# Altium schematic sheet AD转化量化器.SchDoc(AD转化量化器)
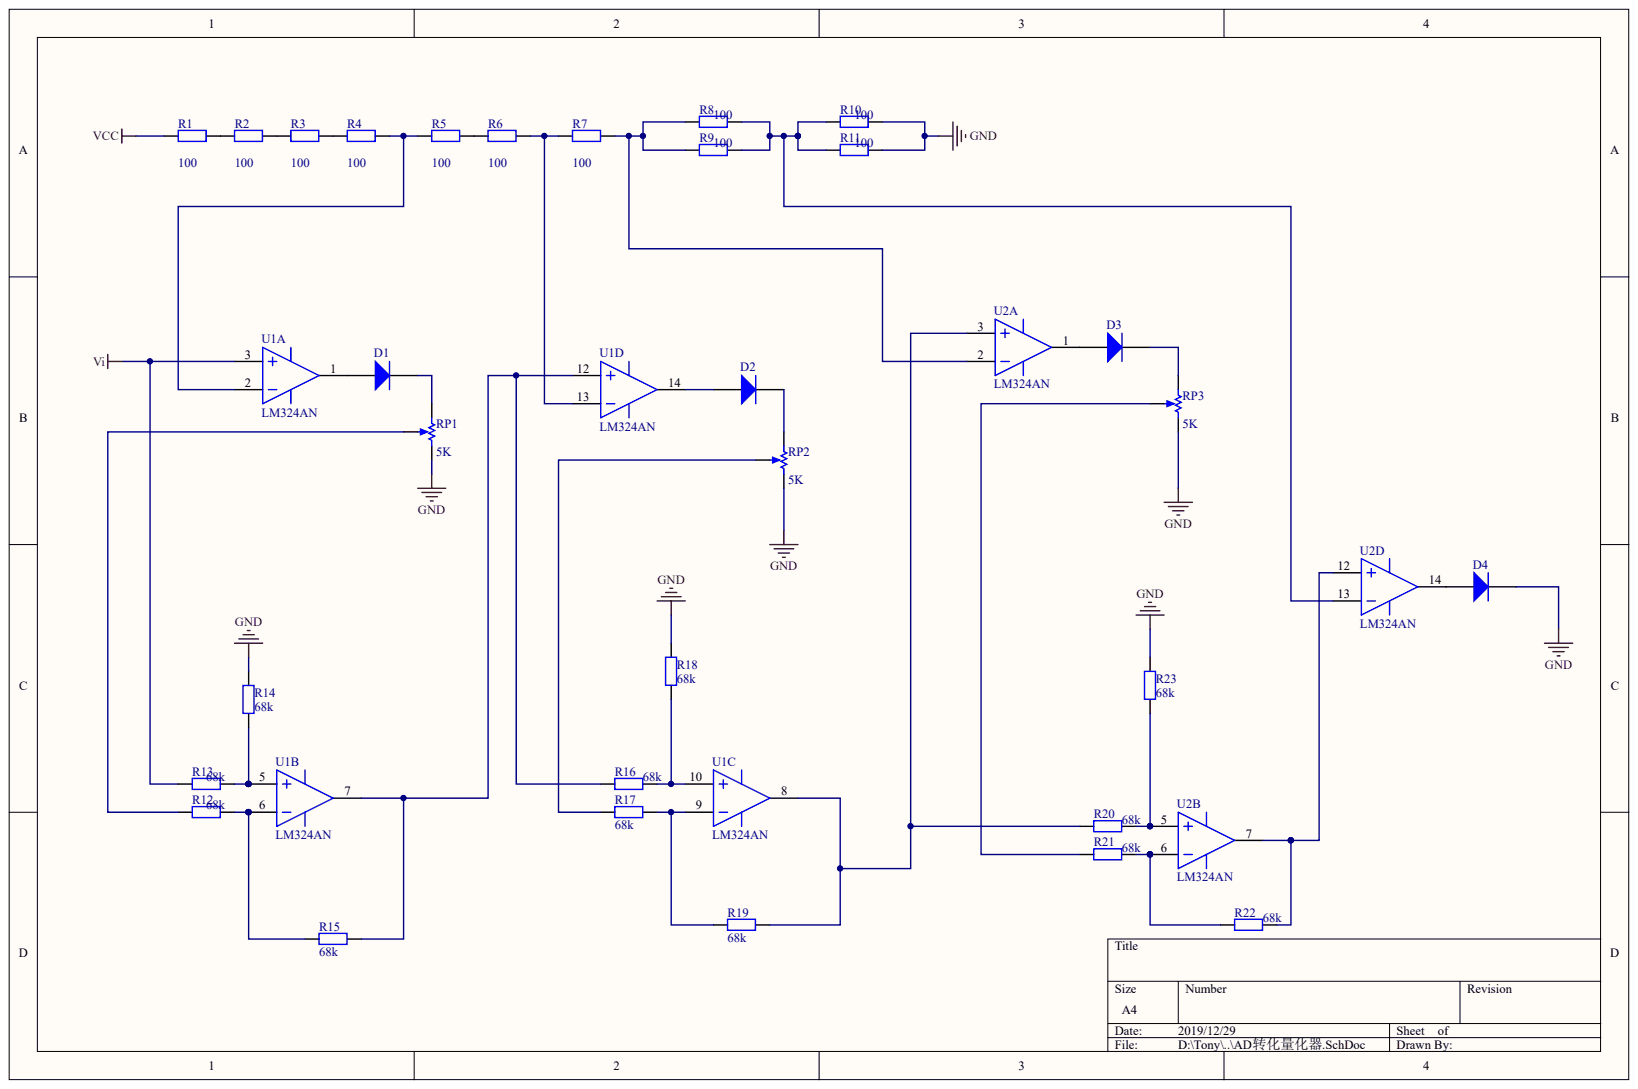
[diagram p0: AD转化量化器.SchDoc]

## Parts
D1  Diode 1N914  (value not drawn)
D2  Diode 1N914  (value not drawn)
D3  Diode 1N914  (value not drawn)
D4  Diode 1N914  (value not drawn)
R1  value 100  (hidden comment: Res2)
R2  value 100  (hidden comment: Res2)
R3  value 100  (hidden comment: Res2)
R4  value 100  (hidden comment: Res2)
R5  value 100  (hidden comment: Res2)
R6  value 100  (hidden comment: Res2)
R7  value 100  (hidden comment: Res2)
R8  value 100  (hidden comment: Res2)
R9  value 100  (hidden comment: Res2)
R10  value 100  (hidden comment: Res2)
R11  Res2  (value not drawn)
R12  value 68k  (hidden comment: Res2)
R13  value 68k  (hidden comment: Res2)
R14  value 68k  (hidden comment: Res2)
R15  value 68k  (hidden comment: Res2)
R16  value 68k  (hidden comment: Res2)
R17  value 68k  (hidden comment: Res2)
R18  value 68k  (hidden comment: Res2)
R19  value 68k  (hidden comment: Res2)
R20  value 68k  (hidden comment: Res2)
R21  value 68k  (hidden comment: Res2)
R22  value 68k  (hidden comment: Res2)
R23  value 68k  (hidden comment: Res2)
RP1  value 5K  (hidden comment: RPot)
RP2  value 5K  (hidden comment: RPot)
RP3  value 5K  (hidden comment: RPot)
U1  LM324AN  (parts A,B,C,D)
U2  LM324AN  (parts A,B,D)

## Nets
GND: D4.2 R10.2 R11.2 R14.2 R18.1 R23.2 RP1.2 RP2.2 RP3.2
VCC: R1.1
VI: R13.1 U1.3
NetD1_1: D1.1 U1.1
NetD1_2: D1.2 RP1.1
NetD2_1: D2.1 U1.14
NetD2_2: D2.2 RP2.1
NetD3_1: D3.1 U2.1
NetD3_2: D3.2 RP3.1
NetD4_1: D4.1 U2.14
NetR1_2: R1.2 R2.1
NetR2_2: R2.2 R3.1
NetR3_2: R3.2 R4.1
NetR4_2: R4.2 R5.1 U1.2
NetR5_2: R5.2 R6.1
NetR6_2: R6.2 R7.1 U1.13
NetR7_2: R7.2 R8.1 R9.1 U2.2
NetR8_2: R8.2 R9.2 R10.1 R11.1 U2.13
NetR12_1: R12.1 RP1.3
NetR12_2: R12.2 R15.2 U1.6
NetR13_2: R13.2 R14.1 U1.5
NetR15_1: R15.1 R16.2 U1.7 U1.12
NetR16_1: R16.1 R18.2 U1.10
NetR17_1: R17.1 R19.1 U1.9
NetR17_2: R17.2 RP2.3
NetR19_2: R19.2 R20.1 U1.8 U2.3
NetR20_2: R20.2 R23.1 U2.5
NetR21_1: R21.1 RP3.3
NetR21_2: R21.2 R22.1 U2.6
NetR22_2: R22.2 U2.7 U2.12

## Library data of the parts
R11 = (no symbol name): Value: 100; Published: 8-Jun-2000; LatestRevisionDate: 17-Jul-2002; LatestRevisionNote: Re-released for DXP Platform.; PackageReference: AXIAL-0.4; PackageDescription: Axial Device, Thru-Hole; 2 Leads; 0.4 in Pin Spacing; Publisher: Altium Limited
RP1-RP3 = (no symbol name): Sim Note: Enter the Resistance value and the set point into parameter fields.; Set Position: 0.5; Published: 8-Jun-2000; LatestRevisionDate: 17-Jul-2002; LatestRevisionNote: Re-released for DXP Platform.; PackageReference: VR5; PackageDescription: Variable Resistor, Thru-Hole; 3 Leads; Publisher: Altium Limited
U1 U2 = (no symbol name): Manufacturer: National Semiconductor; PackageTopMarking: NSUZXYYTTE#*LM324AN; PowerWise: N; CompType: Amplifiers; Published: 4-Dec-2008; LatestRevisionDate: 13-Jan-2009; LatestRevisionNote: Sim Model Added.; DatasheetVersion: Aug-2000; PackageVersion: revG, May-2006; PackageReference: N14A; PackageDescription: MDIP, .750 x .250 x.130in, 14 Lead, .100in Pitch; Supplier 1: Farnell; Supplier Part Number 1: 9486917; PartNumber: LM324AN; RoHS: N; PinCount: 14; Code_JEDEC: MS-001-AA; ComponentLink1Description: LM324 Datasheet; ComponentLink1URL: http://www.national.com/ds/LM/LM324.pdf; ComponentLink2Description: LM324 Web Page; ComponentLink2URL: http://www.national.com/pf/LM/LM324.html; ComponentLink3Description: N14A Package Page; ComponentLink3URL: http://www.national.com/packaging/folders/n14a.html; Publisher: Altium Limited
D1-D4 R1-R10 R12-R23 = (no symbol name): Published: 8-Jun-2000; LatestRevisionDate: 17-Jul-2002; LatestRevisionNote: Re-released for DXP Platform.; Publisher: Altium Limited
  D1-D4: DatasheetVersion: 1997; PackageReference: DO-35; PackageDescription: Diode, Axial; Body 3.8 x 1.9 mm (LxDia. max), Lead Dia. 0.53 mm (max); Code_JEDEC: DO-204-AH; ComponentLink1Description: Manufacturer Link; ComponentLink1URL: http://www.fairchildsemi.com/; ComponentLink2Description: Datasheet; ComponentLink2URL: http://www.fairchildsemi.com/ds/1N%2F1N4448.pdf
  R1-R10 R12-R23: PackageReference: AXIAL-0.4; PackageDescription: Axial Device, Thru-Hole; 2 Leads; 0.4 in Pin Spacing
